# Altium schematic sheet TPS5430_buck_converter.SchDoc(TPS5430_buck_converter) (continued)
## Library data of the parts (continued)
U1 = TPS5430DDAR: 5.5V to 36V Input, 3A, 500kHz Step-Down Converter 8-SO PowerPAD -40 to 125; Manufacturer: Texas Instruments; Manufacturer Lifecycle Status: ACTIVE; Efficiency: 95%; Max Duty Cycle: 89%; Max Junction Temperature (Tj): 125°C; Max Output Voltage: 31V; Min Output Voltage: 1.22V; Number of Channels: 1; Output Type: Adjustable; Quiescent Current: 3mA; Synchronous Rectifier: No; Topology: Buck; Case/Package: SOIC; Category: Voltage Regulators - Switching; Component Kind: Standard; ComponentLink1Description: https://sigma.octopart.com:443/104526336/technical_drawing/Texas-Instruments-TPS5430DDAR.pdf?src-supplier=Texas+Instruments; ComponentLink1URL: https://sigma.octopart.com:443/104526336/technical_drawing/Texas-Instruments-TPS5430DDAR.pdf?src-supplier=Texas+Instruments; ComponentLink2Description: https://octopart.com:443/opatz8j6/c1?t=f7jUAzZkle-Fjb72V6eBYCuWrcUHLfucGMUdbsgDZ3Fmre6oMj4pLxI-LB-MFByLzhDYZj9rUEcTMn_Uvuy45t5vXkTnrUWzROXJ9UnIKGMBM_1tAdWpMY30zPAo7aghPEBtuXeEGrdQl48pyx6m-plsXYshdZt8XpA72AOsk-3nRqkMNvwuTJAduuC8Q07ryefmaYqZXLZER76RsEhEikmJZzLEFH5E4H9HzAHCMllXb9oFwaUXXre3Bl_5p1k8U86IFgFe3_FkMs4-t0seF3s8c2upZg1Wkr1djqNz1WZQc4EaMoj0X0NGUTOFY-lOI4VKt-PHw4QlENP11s9Op8dlYRfAE7OEapBARdfkgfbcVzxTWgIIko50LlT_ijPwX65DZw&src-supplier=Texas+Instruments; ComponentLink2URL: https://octopart.com:443/opatz8j6/c1?t=f7jUAzZkle-Fjb72V6eBYCuWrcUHLfucGMUdbsgDZ3Fmre6oMj4pLxI-LB-MFByLzhDYZj9rUEcTMn_Uvuy45t5vXkTnrUWzROXJ9UnIKGMBM_1tAdWpMY30zPAo7aghPEBtuXeEGrdQl48pyx6m-plsXYshdZt8XpA72AOsk-3nRqkMNvwuTJAduuC8Q07ryefmaYqZXLZER76RsEhEikmJZzLEFH5E4H9HzAHCMllXb9oFwaUXXre3Bl_5p1k8U86IFgFe3_FkMs4-t0seF3s8c2upZg1Wkr1djqNz1WZQc4EaMoj0X0NGUTOFY-lOI4VKt-PHw4QlENP11s9Op8dlYRfAE7OEapBARdfkgfbcVzxTWgIIko50LlT_ijPwX65DZw&src-supplier=Texas+Instruments; ComponentLink3Description: https://datasheet.octopart.com:443/TPS5430DDAR-Texas-Instruments-datasheet-34154659.pdf?src-supplier=Texas+Instruments; ComponentLink3URL: https://datasheet.octopart.com:443/TPS5430DDAR-Texas-Instruments-datasheet-34154659.pdf?src-supplier=Texas+Instruments; ComponentLink4Description: https://datasheet.octopart.com:443/TPS5430DDAR-Texas-Instruments-datasheet-17423535.pdf?src-supplier=Texas+Instruments; ComponentLink4URL: https://datasheet.octopart.com:443/TPS5430DDAR-Texas-Instruments-datasheet-17423535.pdf?src-supplier=Texas+Instruments; ComponentLink5Description: https://datasheet.octopart.com:443/TPS5430DDAR-Texas-Instruments-datasheet-13479706.pdf?src-supplier=Texas+Instruments; ComponentLink5URL: https://datasheet.octopart.com:443/TPS5430DDAR-Texas-Instruments-datasheet-13479706.pdf?src-supplier=Texas+Instruments; ComponentLink6Description: https://sigma.octopart.com:443/49250132/technical_drawing/Texas-Instruments-TPS5430DDAR.pdf?src-supplier=Texas+Instruments; ComponentLink6URL: https://sigma.octopart.com:443/49250132/technical_drawing/Texas-Instruments-TPS5430DDAR.pdf?src-supplier=Texas+Instruments; ComponentLink7Description: https://datasheet.octopart.com:443/TPS5430DDAR-Texas-Instruments-datasheet-10258285.pdf?src-supplier=Texas+Instruments; ComponentLink7URL: https://datasheet.octopart.com:443/TPS5430DDAR-Texas-Instruments-datasheet-10258285.pdf?src-supplier=Texas+Instruments; ComponentLink8Description: https://datasheet.octopart.com:443/TPS5430DDAR-Texas-Instruments-datasheet-151806.pdf?src-supplier=Texas+Instruments; ComponentLink8URL: https://datasheet.octopart.com:443/TPS5430DDAR-Texas-Instruments-datasheet-151806.pdf?src-supplier=Texas+Instruments; ComponentLink9Description: https://datasheet.octopart.com:443/TPS5430DDAR-Texas-Instruments-datasheet-136392.pdf?src-supplier=Texas+Instruments; ComponentLink9URL: https://datasheet.octopart.com:443/TPS5430DDAR-Texas-Instruments-datasheet-136392.pdf?src-supplier=Texas+Instruments; ComponentLink10Description: https://datasheet.octopart.com:443/TPS5430DDAR-Texas-Instruments-datasheet-37140813.pdf?src-supplier=Newark; ComponentLink10URL: https://datasheet.octopart.com:443/TPS5430DDAR-Texas-Instruments-datasheet-37140813.pdf?src-supplier=Newark; ComponentLink11Description: https://datasheet.octopart.com:443/TPS5430DDAR-Texas-Instruments-datasheet-62314223.pdf?src-supplier=Newark; ComponentLink11URL: https://datasheet.octopart.com:443/TPS5430DDAR-Texas-Instruments-datasheet-62314223.pdf?src-supplier=Newark; ComponentLink12Description: https://datasheet.octopart.com:443/TPS5430DDAR-Texas-Instruments-datasheet-21262768.pdf?src-supplier=Newark; ComponentLink12URL: https://datasheet.octopart.com:443/TPS5430DDAR-Texas-Instruments-datasheet-21262768.pdf?src-supplier=Newark; ComponentLink13Description: https://datasheet.octopart.com:443/TPS5430DDAR-Texas-Instruments-datasheet-14439094.pdf?src-supplier=Upverter; ComponentLink13URL: https://datasheet.octopart.com:443/TPS5430DDAR-Texas-Instruments-datasheet-14439094.pdf?src-supplier=Upverter; ComponentLink14Description: https://datasheet.octopart.com:443/TPS5430DDAR-Texas-Instruments-datasheet-188384434.pdf?src-supplier=LCSC; ComponentLink14URL: https://datasheet.octopart.com:443/TPS5430DDAR-Texas-Instruments-datasheet-188384434.pdf?src-supplier=LCSC; ComponentLink15Description: https://datasheet.octopart.com:443/TPS5430DDAR-Texas-Instruments-datasheet-165825678.pdf?src-supplier=LCSC; ComponentLink15URL: https://datasheet.octopart.com:443/TPS5430DDAR-Texas-Instruments-datasheet-165825678.pdf?src-supplier=LCSC; ComponentLink16Description: https://datasheet.octopart.com:443/TPS5430DDAR-Texas-Instruments-datasheet-159118078.pdf?src-supplier=LCSC; ComponentLink16URL: https://datasheet.octopart.com:443/TPS5430DDAR-Texas-Instruments-datasheet-159118078.pdf?src-supplier=LCSC; ComponentLink17Description: https://datasheet.octopart.com:443/TPS5430DDAR-Texas-Instruments-datasheet-11761703.pdf?src-supplier=element14+APAC; ComponentLink17URL: https://datasheet.octopart.com:443/TPS5430DDAR-Texas-Instruments-datasheet-11761703.pdf?src-supplier=element14+APAC; ComponentLink18Description: https://datasheet.octopart.com:443/TPS5430DDAR-Texas-Instruments-datasheet-137316104.pdf?src-supplier=Future+Electronics; ComponentLink18URL: https://datasheet.octopart.com:443/TPS5430DDAR-Texas-Instruments-datasheet-137316104.pdf?src-supplier=Future+Electronics; ComponentLink19Description: https://datasheet.octopart.com:443/TPS5430DDAR-Texas-Instruments-datasheet-14167055.pdf?src-supplier=iiiC; ComponentLink19URL: https://datasheet.octopart.com:443/TPS5430DDAR-Texas-Instruments-datasheet-14167055.pdf?src-supplier=iiiC; ComponentLink20Description: https://datasheet.octopart.com:443/TPS5430DDAR-Texas-Instruments-datasheet-11724979.pdf?src-supplier=Arrow+Electronics; ComponentLink20URL: https://datasheet.octopart.com:443/TPS5430DDAR-Texas-Instruments-datasheet-11724979.pdf?src-supplier=Arrow+Electronics; ComponentLink21Description: https://datasheet.octopart.com:443/TPS5430DDAR-Texas-Instruments-datasheet-8429814.pdf?src-supplier=Jameco; ComponentLink21URL: https://datasheet.octopart.com:443/TPS5430DDAR-Texas-Instruments-datasheet-8429814.pdf?src-supplier=Jameco; ComponentLink22Description: http://sigma.octopart.com:80/reach_statement/Texas-Instruments-company-64.pdf?src-supplier=Compliance+Statements; ComponentLink22URL: http://sigma.octopart.com:80/reach_statement/Texas-Instruments-company-64.pdf?src-supplier=Compliance+Statements; ComponentLink23Description: http://sigma.octopart.com:80/conflict_mineral_statement/Texas-Instruments-company-63.pdf?src-supplier=Compliance+Statements; ComponentLink23URL: http://sigma.octopart.com:80/conflict_mineral_statement/Texas-Instruments-company-63.pdf?src-supplier=Compliance+Statements; Height: 1.7mm; Lead Free: Lead Free; Length: 4.89mm; Library Name: TPS5430_buck _converter.SchLib; Lifecycle Status: Production; Manufacturer Part Number: TPS5430DDAR; Max Operating Temperature: 125°C; Max Output current: 3A; Max Supply Voltage: 36V; Min Input Voltage: 5.5V; Min Operating Temperature: -40°C; Min Supply Voltage: 5.5V; Mounting Technology: Surface Mount; Number of Outputs: 1; Operating Supply Voltage: 36V; Output Current: 3A; Output Voltage: 31V; Pins: 8; Pricing: 1=4.34, 10=3.28, 50=3.02, 100=2.73, 1000=2.44, 10000=2.37 (USD); Radiation Hardening: No; RoHS: Compliant; Schedule B: 8542390000, 8542390000|8542390000|8542390000|8542390000|8542390000; Stock: 8124; Supplier: Mouser; Supplier Part Number: 595-TPS5430DDAR; Switching Frequency: 500kHz; Thickness: 1.48mm; Weight: 70.590313mg; Width: 3.9mm
